# Altium schematic sheet Sch_Sh3.SchDoc
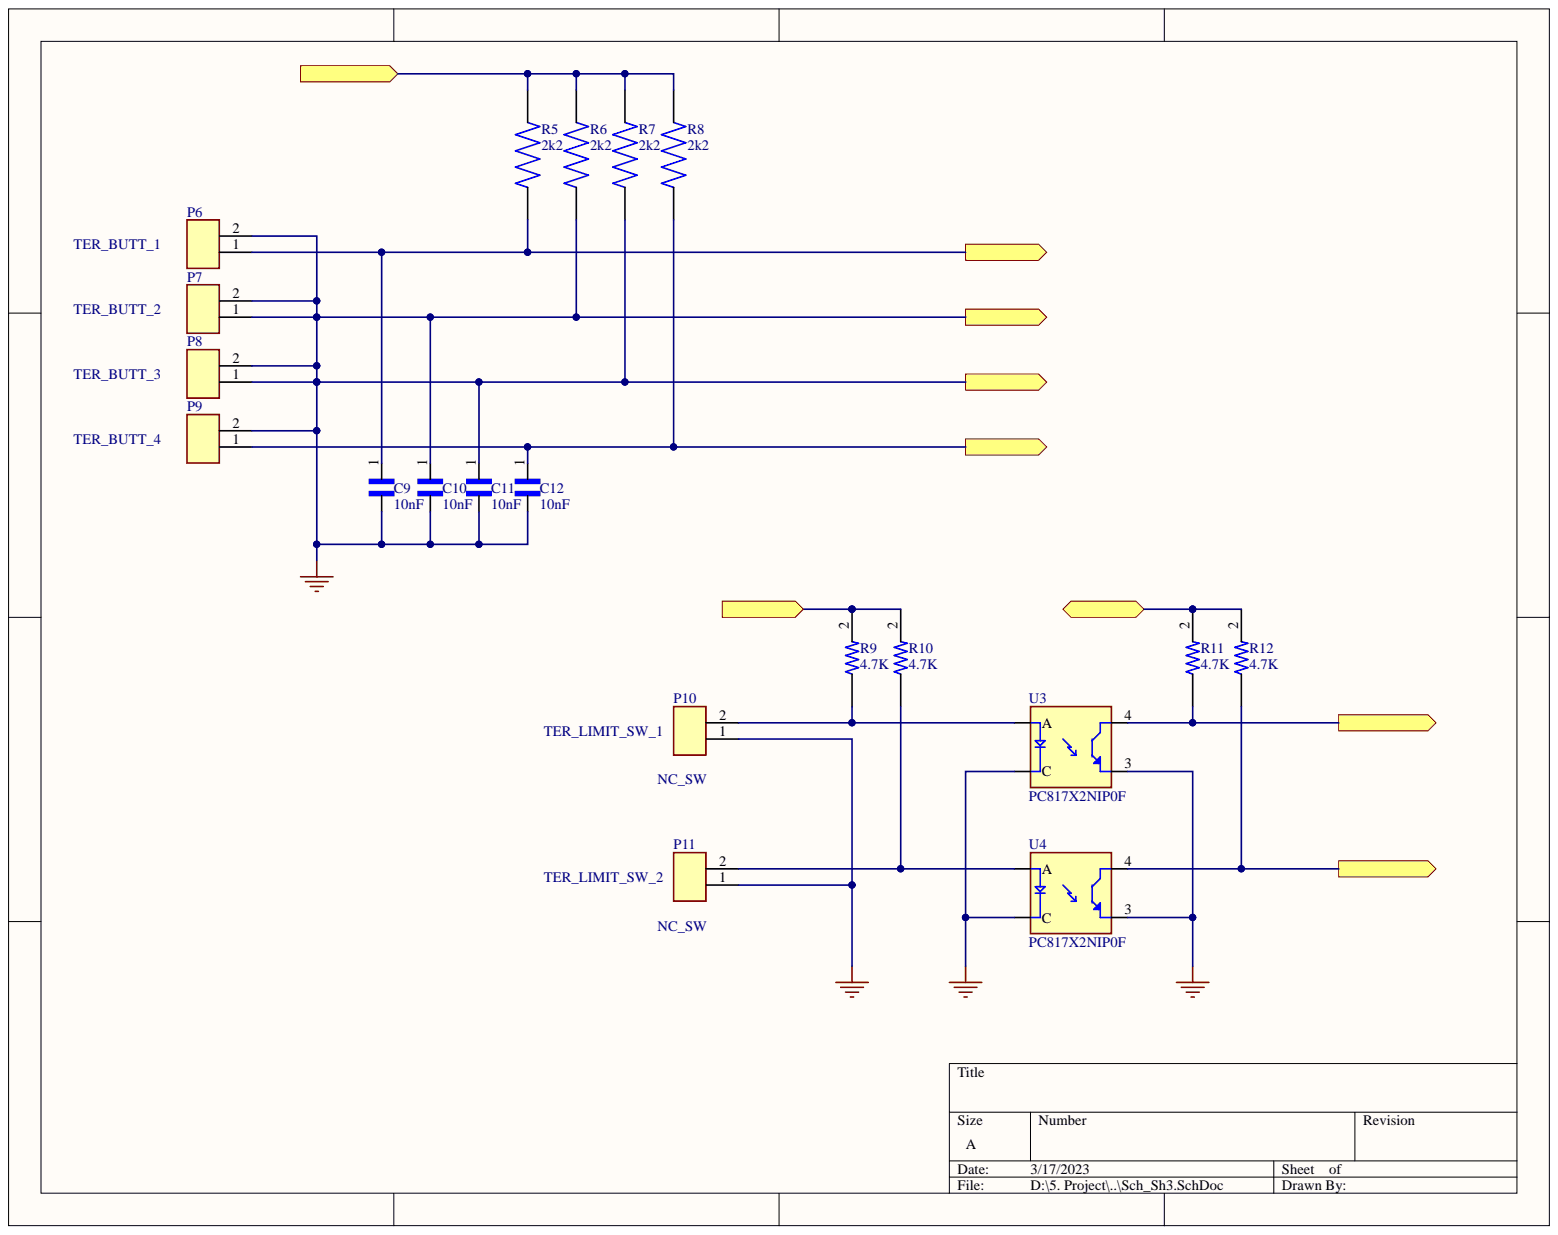
[diagram p0: Sch_Sh3.SchDoc]

## Parts
C9  10nF  [CAPC1005X55N]
C10  10nF  [CAPC1005X55N]
C11  10nF  [CAPC1005X55N]
C12  10nF  [CAPC1005X55N]
P6  Button_1  [TE_282837-2]  (value not drawn)
P7  282837-2  [TE_282837-2]  (value not drawn)
P8  282837-2  [TE_282837-2]  (value not drawn)
P9  282837-2  [TE_282837-2]  (value not drawn)
P10  Comment_1: TER_LIMIT_SW_1  [TE_282837-2]  (hidden comment: 282837-2)
P11  Comment_1: TER_LIMIT_SW_2  [TE_282837-2]  (hidden comment: 282837-2)
R5  2k2  [RES_ERJ3EKF2201V]
R6  2k2  [RES_ERJ3EKF2201V]
R7  2k2  [RES_ERJ3EKF2201V]
R8  2k2  [RES_ERJ3EKF2201V]
R9  4.7K  [RESC1607X60N]
R10  4.7K  [RESC1607X60N]
R11  4.7K  [RESC1607X60N]
R12  4.7K  [RESC1607X60N]
U3  PC817X2NIP0F  [SOT254P975X400-4N]
U4  PC817X2NIP0F  [SOT254P975X400-4N]

## Ports
3.3v, 24VDC, Button_1, Button_2, Button_3, Button_4, Limit_SW_1, Limit_SW_2, MCU_3.3V

## Nets
3.3v: R11.2 R12.2 ; port 3.3v
24VDC: R9.2 R10.2 ; port 24VDC
Button_1: C9.1 P6.1 R5.1 ; port Button_1
Button_4: C12.1 P9.1 R8.1 ; port Button_4
GND: C9.2 C10.1 C10.2 C11.1 C11.2 C12.2 P6.2 P7.1 P7.2 P8.1 P8.2 P9.2 P10.1 P11.1 R6.1 R7.1 U3.2(C) U3.3 U4.2(C) U4.3 ; port Button_2, port Button_3
Limit_SW_1: R11.1 U3.4 ; port Limit_SW_1
Limit_SW_2: R12.1 U4.4 ; port Limit_SW_2
MCU_3.3V: R5.2 R6.2 R7.2 R8.2 ; port MCU_3.3V
NetP10_2: P10.2 R9.1 U3.1(A)
NetP11_2: P11.2 R10.1 U4.1(A)

## Library data of the parts
C9-C12 = CC0402KRX7R9BB103: 10000 pF Â±10% 50V Ceramic Capacitor X7R 0402 (1005 Metric); MF: Yageo; Availability: In Stock; Check_prices: https://www.snapeda.com/parts/CC0402KRX7R9BB103/Yageo/view-part/?ref=eda; Component Kind: Standard; Library Name: CC0402KRX7R9BB103.IntLib; MP: CC0402KRX7R9BB103; Package: 0402 Yageo; Price: None; Purchase-URL: https://pricing.snapeda.com/search/part/CC0402KRX7R9BB103/?ref=eda; SnapEDA_Link: https://www.snapeda.com/parts/CC0402KRX7R9BB103/Yageo/view-part/?ref=snap
P6 = 282837-2: Connector Terminal Blocks Receptacle 2 Position 5.08mm Solder Straight Thru-Hole 13.5A/Contact; Comment_1: TER_BUTT_1; MF: TE Connectivity; Availability: In Stock; Check_prices: https://www.snapeda.com/parts/282837-2/TE+Connectivity+AMP+Connectors/view-part/?ref=eda; Component Kind: Standard; Library Name: Con_TerminalBlock_2Pin_5.08mm.IntLib; MP: 282837-2; Package: None; Price: None; Purchase-URL: https://pricing.snapeda.com/search/part/282837-2/?ref=eda; SnapEDA_Link: https://www.snapeda.com/parts/282837-2/TE+Connectivity+AMP+Connectors/view-part/?ref=snap
P7 = 282837-2: Connector Terminal Blocks Receptacle 2 Position 5.08mm Solder Straight Thru-Hole 13.5A/Contact; Comment_1: TER_BUTT_2; MF: TE Connectivity; Availability: In Stock; Check_prices: https://www.snapeda.com/parts/282837-2/TE+Connectivity+AMP+Connectors/view-part/?ref=eda; Component Kind: Standard; Library Name: Con_TerminalBlock_2Pin_5.08mm.IntLib; MP: 282837-2; Package: None; Price: None; Purchase-URL: https://pricing.snapeda.com/search/part/282837-2/?ref=eda; SnapEDA_Link: https://www.snapeda.com/parts/282837-2/TE+Connectivity+AMP+Connectors/view-part/?ref=snap
P8 = 282837-2: Connector Terminal Blocks Receptacle 2 Position 5.08mm Solder Straight Thru-Hole 13.5A/Contact; Comment_1: TER_BUTT_3; MF: TE Connectivity; Availability: In Stock; Check_prices: https://www.snapeda.com/parts/282837-2/TE+Connectivity+AMP+Connectors/view-part/?ref=eda; Component Kind: Standard; Library Name: Con_TerminalBlock_2Pin_5.08mm.IntLib; MP: 282837-2; Package: None; Price: None; Purchase-URL: https://pricing.snapeda.com/search/part/282837-2/?ref=eda; SnapEDA_Link: https://www.snapeda.com/parts/282837-2/TE+Connectivity+AMP+Connectors/view-part/?ref=snap
P9 = 282837-2: Connector Terminal Blocks Receptacle 2 Position 5.08mm Solder Straight Thru-Hole 13.5A/Contact; Comment_1: TER_BUTT_4; MF: TE Connectivity; Availability: In Stock; Check_prices: https://www.snapeda.com/parts/282837-2/TE+Connectivity+AMP+Connectors/view-part/?ref=eda; Component Kind: Standard; Library Name: Con_TerminalBlock_2Pin_5.08mm.IntLib; MP: 282837-2; Package: None; Price: None; Purchase-URL: https://pricing.snapeda.com/search/part/282837-2/?ref=eda; SnapEDA_Link: https://www.snapeda.com/parts/282837-2/TE+Connectivity+AMP+Connectors/view-part/?ref=snap
P10 P11 = 282837-2: Connector Terminal Blocks Receptacle 2 Position 5.08mm Solder Straight Thru-Hole 13.5A/Contact; MF: TE Connectivity; : NC_SW; Availability: In Stock; Check_prices: https://www.snapeda.com/parts/282837-2/TE+Connectivity+AMP+Connectors/view-part/?ref=eda; Component Kind: Standard; Library Name: Con_TerminalBlock_2Pin_5.08mm.IntLib; MP: 282837-2; Package: None; Price: None; Purchase-URL: https://pricing.snapeda.com/search/part/282837-2/?ref=eda; SnapEDA_Link: https://www.snapeda.com/parts/282837-2/TE+Connectivity+AMP+Connectors/view-part/?ref=snap
R5-R8 = ERJ3EKF2201V: 2.2 kOhms 1% 0.1W, 1/10W Chip Resistor 0603 (1608 Metric) Automotive AEC-Q200 Thick Film; MF: Panasonic; MP: ERJ-3EKF2201V; Check_prices: https://www.snapeda.com/parts/ERJ-3EKF2201V/Panasonic%20Electronic%20Components/view-part/371927/?ref=eda; Component Kind: Standard; Digi-Key_Part_Number: P2.20KHCT-ND; Library Name: ERJ3EKF2201V.IntLib; Package: 1608-2 Panasonic; SnapEDA_Link: https://www.snapeda.com/parts/ERJ-3EKF2201V/Panasonic%20Electronic%20Components/view-part/371927/?ref=snap
R9-R12 = RC0603FR-074K7L: 4.7 kOhms Â±1% 0.1W, 1/10W Chip Resistor 0603 _1608 Metric_ Moisture Resistant Thick Film; MF: Yageo; Availability: Unavailable; Component Kind: Standard; Library Name: RES_4.7_KRC0603FR-074K7L.IntLib; MP: RC0603FR-074K7L; Package: 0603 Yageo; Price: None; SnapEDA_Link: https://www.snapeda.com/parts/RC0603FR-074K7L/Yageo/view-part/473520/?ref=snap
U3 U4 = PC817X2NIP0F: Optoisolator Transistor Output 5000Vrms 1 Channel 4-SMD; MF: Sharp Microelectronics; Check_prices: https://www.snapeda.com/parts/PC817X2NIP0F/Sharp+Microelectronics/view-part/?ref=eda; Component Kind: Standard; Digi-Key_Part_Number: 425-2192-2-ND; Library Name: PC817X2NIP0F.IntLib; MP: PC817X2NIP0F; Package: SMD-4 Sharp; Purchase-URL: https://pricing.snapeda.com/search/part/PC817X2NIP0F/?ref=eda; SnapEDA_Link: https://www.snapeda.com/parts/PC817X2NIP0F/Sharp+Microelectronics/view-part/?ref=snap
